# Altium schematic sheet Sheet1.SchDoc(Sheet1)
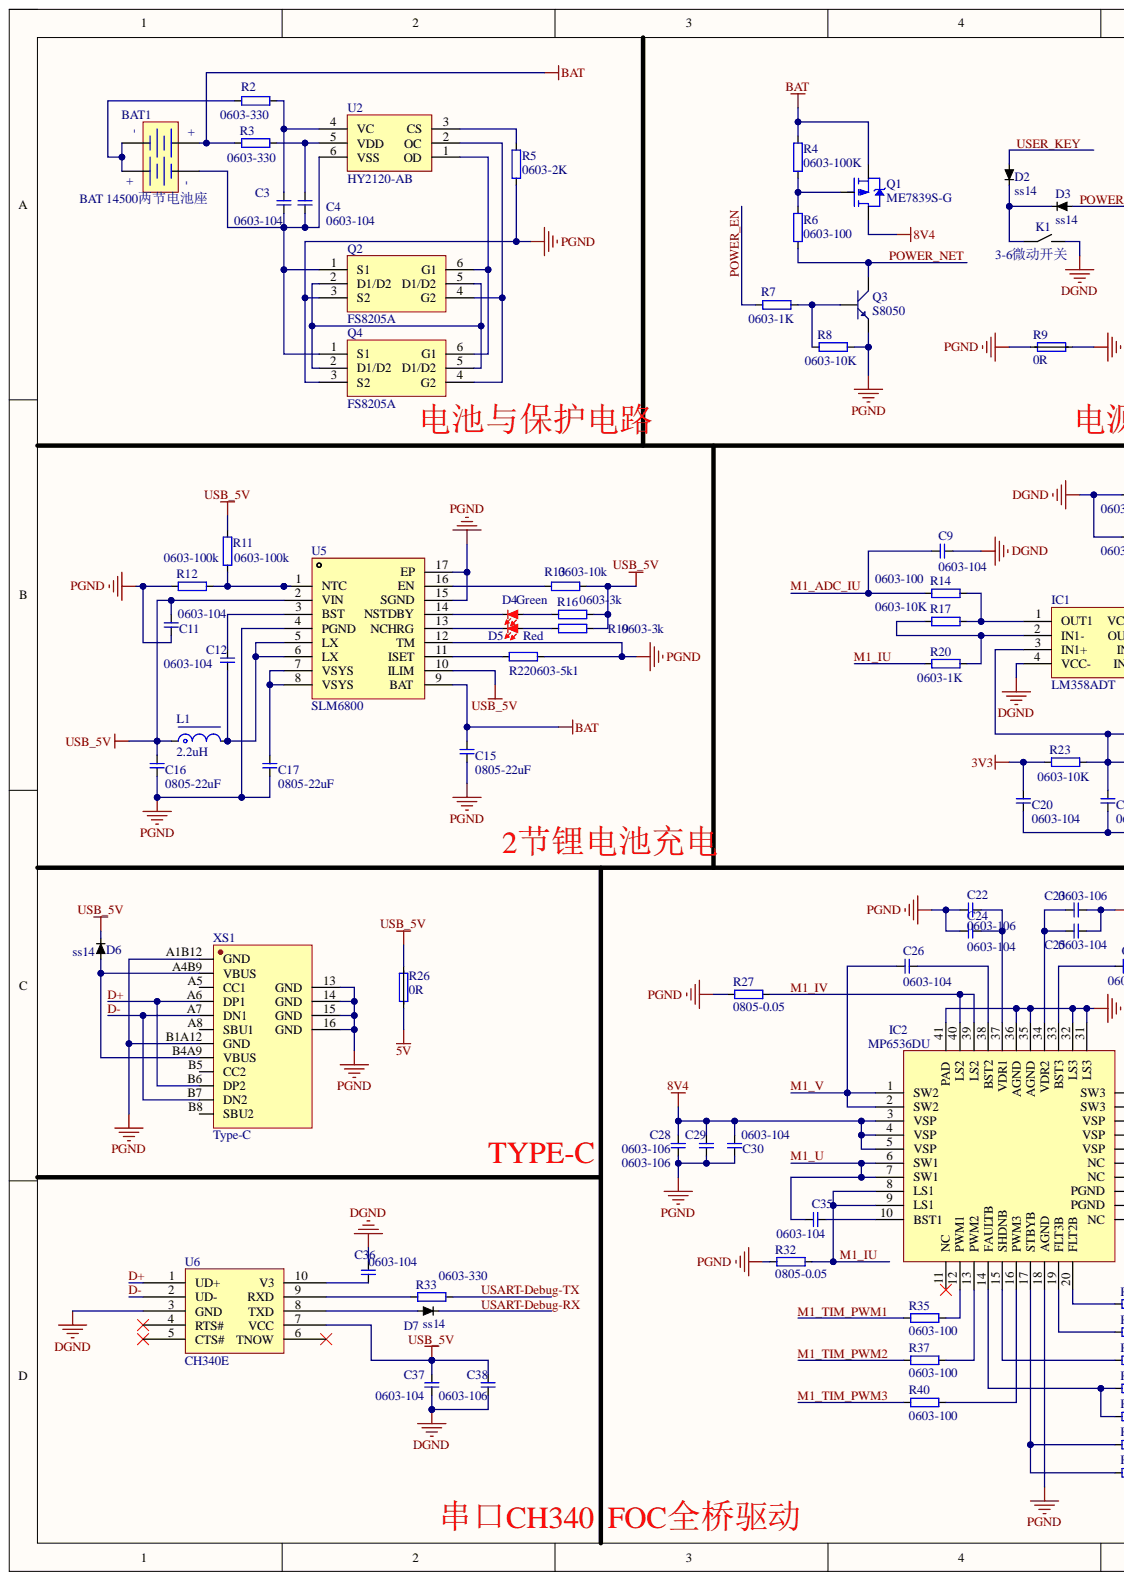
[diagram p0: Sheet1.SchDoc, left]
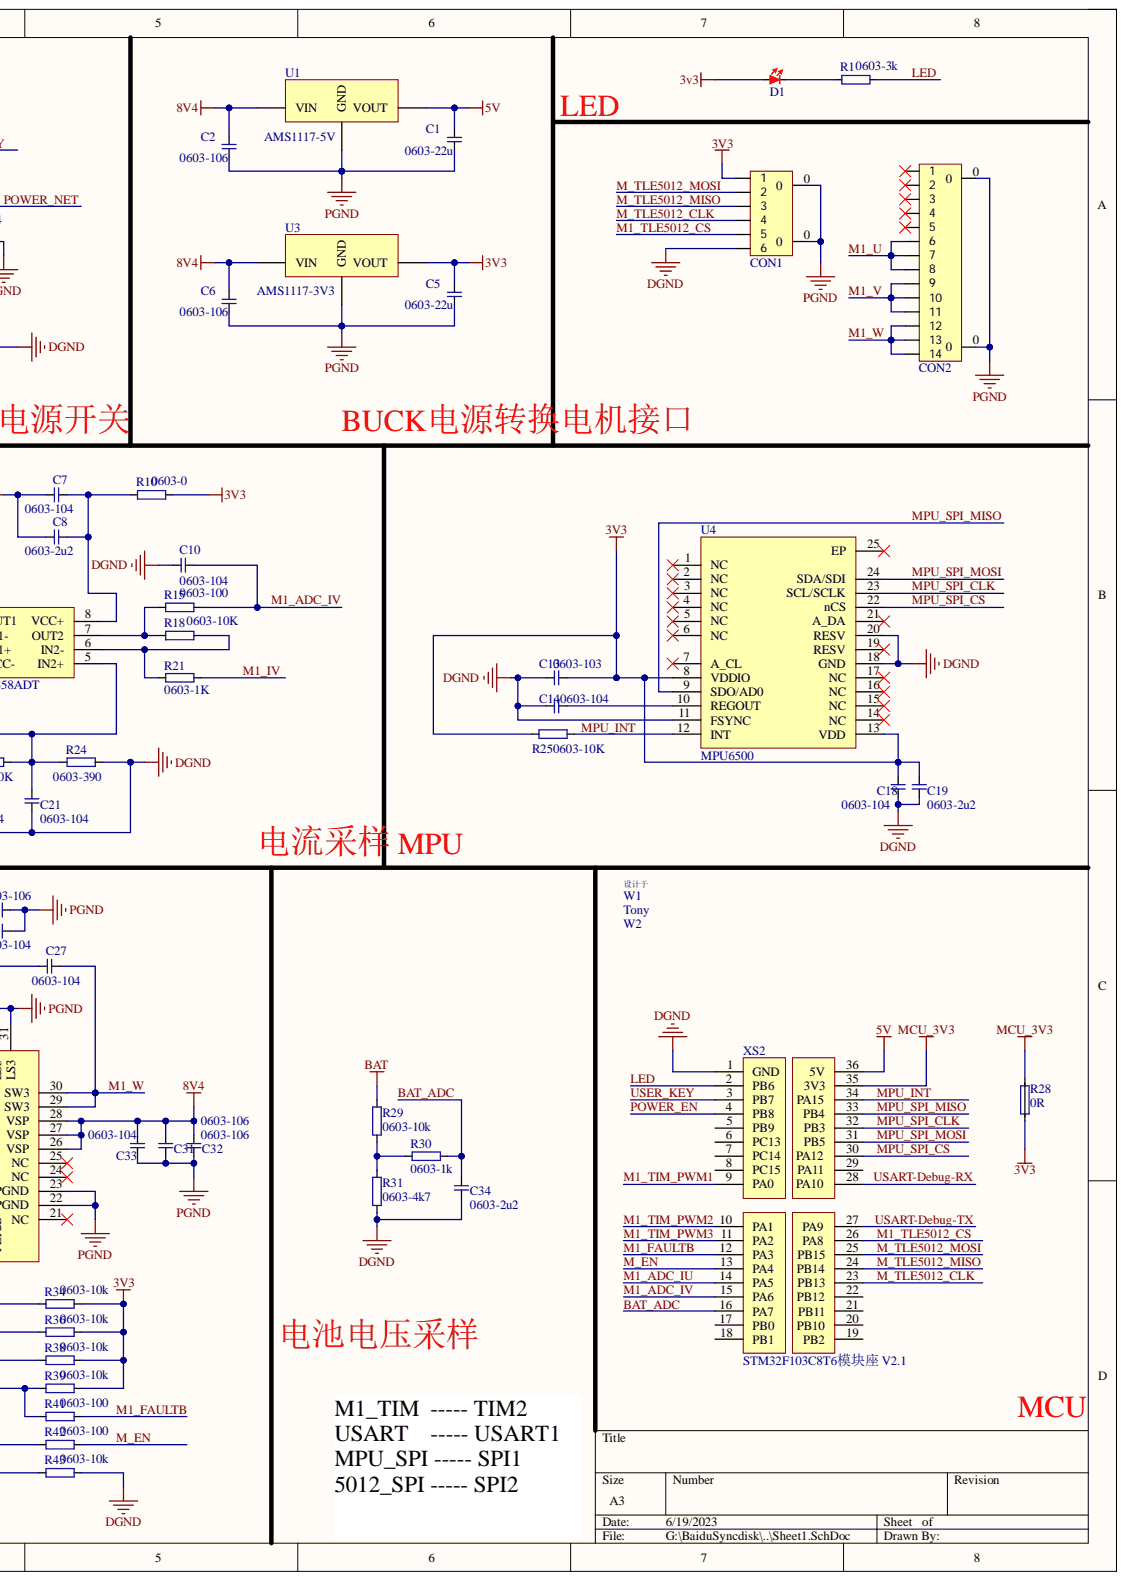
[diagram p1: Sheet1.SchDoc, right]

## Parts
BAT1  BAT 14500两节电池座  [BAT5#x2 电池座]
C1  0603-22u  [CAP_0603]
C2  0603-106  [CAP_0603]
C3  0603-104  [CAP_0603]
C4  0603-104  [CAP_0603]
C5  0603-22u  [CAP_0603]
C6  0603-106  [CAP_0603]
C7  0603-104  [CAP_0603]
C8  0603-2u2  [CAP_0603]
C9  0603-104  [CAP_0603]
C10  0603-104  [CAP_0603]
C11  0603-104  [CAP_0603]
C12  0603-104  [CAP_0603]
C13  0603-103  [CAP_0603]
C14  0603-104  [CAP_0603]
C15  0805-22uF  [CAP_0805]
C16  0805-22uF  [CAP_0805]
C17  0805-22uF  [CAP_0805]
C18  0603-104  [CAP_0603]
C19  0603-2u2  [CAP_0603]
C20  0603-104  [CAP_0603]
C21  0603-104  [CAP_0603]
C22  0603-106  [CAP_0603]
C23  0603-106  [CAP_0603]
C24  0603-104  [CAP_0603]
C25  0603-104  [CAP_0603]
C26  0603-104  [CAP_0603]
C27  0603-104  [CAP_0603]
C28  0603-106  [CAP_0603]
C29  0603-106  [CAP_0603]
C30  0603-104  [CAP_0603]
C31  0603-106  [CAP_0603]
C32  0603-106  [CAP_0603]
C33  0603-104  [CAP_0603]
C34  0603-2u2  [CAP_0603]
C35  0603-104  [CAP_0603]
C36  0603-104  [CAP_0603]
C37  0603-104  [CAP_0603]
C38  0603-106  [CAP_0603]
CON1  FFC_0.6  [FFC_0.5_6]  (value not drawn)
CON2  FFC_0.5_14  [FFC_0.5_14]  (value not drawn)
D1  led  [led0603]  (value not drawn)
D2  ss14  [SOD-323]
D3  ss14  [SOD-323]
D4  Green  [led0603]
D5  Red  [led0603]
D6  ss14  [SOD-323]
D7  ss14  [SOD-323]
IC1  LM358ADT  [SOP8_N]
IC2  MP6536DU  [QFN-40_5X5]
K1  3-6微动开关  [3-6微动开关]
L1  2.2uH  [NR4012]
Q1  ME7839S-G  [SOT23]
Q2  FS8205A  [SOT23-6]
Q3  S8050  [SOT23]
Q4  FS8205A  [SOT23-6]
R1  0603-3k  [RES_0603]
R2  0603-330  [RES_0603]
R3  0603-330  [RES_0603]
R4  0603-100K  [RES_0603]
R5  0603-2K  [RES_0603]
R6  0603-100  [RES_0603]
R7  0603-1K  [RES_0603]
R8  0603-10K  [RES_0603]
R9  0R  [RES_0805-SHORT]
R10  0603-0  [RES_0603]
R11  0603-100k  [RES_0603]
R12  0603-100k  [RES_0603]
R13  0603-10k  [RES_0603]
R14  0603-100  [RES_0603]
R15  0603-100  [RES_0603]
R16  0603-3k  [RES_0603]
R17  0603-10K  [RES_0603]
R18  0603-10K  [RES_0603]
R19  0603-3k  [RES_0603]
R20  0603-1K  [RES_0603]
R21  0603-1K  [RES_0603]
R22  0603-5k1  [RES_0603]
R23  0603-10K  [RES_0603]
R24  0603-390  [RES_0603]
R25  0603-10K  [RES_0603]
R26  0R  [RES_0805-SHORT]
R27  0805-0.05  [RES_0805]
R28  0R  [RES_0805-SHORT]
R29  0603-10k  [RES_0603]
R30  0603-1k  [RES_0603]
R31  0603-4k7  [RES_0603]
R32  0805-0.05  [RES_0805]
R33  0603-330  [RES_0603]
R34  0603-10k  [RES_0603]
R35  0603-100  [RES_0603]
R36  0603-10k  [RES_0603]
R37  0603-100  [RES_0603]
R38  0603-10k  [RES_0603]
R39  0603-10k  [RES_0603]
R40  0603-100  [RES_0603]
R41  0603-100  [RES_0603]
R42  0603-100  [RES_0603]
R43  0603-10k  [RES_0603]
U1  AMS1117-5V  [SOT223]
U2  HY2120-AB  [SOT23-6]
U3  AMS1117-3V3  [SOT223]
U4  MPU6500  [QFN-24_3X3]
U5  SLM6800  [QFN-16_4x4]
U6  CH340E  [MSOP10]
W1  设计于  [designed by（430-70）]
W2  Tony  [Tony_2(200-70)]
XS1  Type-C  [TypeC-304-16BCP]
XS2  STM32F103C8T6模块座 V2.1  [STM32F103C8T6 V2_1座子]

## Nets
3v3: C5.2 C13.2 C18.2 C19.2 C20.1 CON1.1 D1.1 R10.2 R23.2 R25.1 R28.1 R34.2 R36.2 R38.2 R39.2 U3.2 U4.8(VDDIO) U4.13(VDD)
5V: C1.2 R26.1 U1.2 XS2.36(5V)
8V4: C2.2 C6.2 C28.2 C29.2 C30.2 C31.2 C32.2 C33.2 IC2.3(VSP) IC2.4(VSP) IC2.5(VSP) IC2.26(VSP) IC2.27(VSP) IC2.28(VSP) Q1.3 U1.3 U3.3
BAT: BAT1.3 C15.2 Q1.2 R3.1 R4.2 R29.2 U5.9(BAT)
DGND: C7.1 C8.1 C9.1 C10.1 C13.1 C14.1 C18.1 C19.1 C20.2 C21.2 C34.1 C36.2 C37.1 C38.1 CON1.6 IC1.4(VCC-) K1.2 R9.1 R24.1 R31.1 R43.2 U4.11(FSYNC) U4.18(GND) U4.20(RESV) U6.3(GND) XS2.1(GND)
MCU_3V3: R28.2 XS2.35(3V3)
PGND: C1.1 C2.1 C5.1 C6.1 C11.2 C15.1 C16.1 C17.1 C22.1 C23.1 C24.1 C25.1 C28.1 C29.1 C30.1 C31.1 C32.1 C33.1 CON1.0 CON2.0 IC2.18(AGND) IC2.22(PGND) IC2.23(PGND) IC2.31(LS3) IC2.32(LS3) IC2.35(AGND) IC2.36(AGND) IC2.41(PAD) Q2.3(S2) Q3.2 Q4.3(S2) R5.1 R8.1 R9.2 R12.2 R22.1 R27.1 R32.1 U1.1 U3.1 U5.4(PGND) U5.12(TM) U5.15(SGND) U5.17(EP) XS1.13(GND) XS1.14(GND) XS1.15(GND) XS1.16(GND) XS1.A1B12(GND) XS1.B1A12(GND)
USB_5V: C11.1 C16.2 C37.2 C38.2 D6.2 L1.1 R11.2 R13.1 R16.1 R19.1 R26.2 U5.2(VIN) U5.10(ILIM) U6.7(VCC)
BAT_ADC: C34.2 R30.1 XS2.16(PA7)
D+: U6.1(UD+) XS1.A6(DP1) XS1.B6(DP2)
D-: U6.2(UD-) XS1.A7(DN1) XS1.B7(DN2)
LED: R1.2 XS2.2(PB6)
M1_ADC_IU: C9.2 R14.2 XS2.14(PA5)
M1_ADC_IV: C10.2 R15.2 XS2.15(PA6)
M1_FAULTB: R41.2 XS2.12(PA3)
M1_IU: IC2.8(LS1) IC2.9(LS1) R20.2 R32.2
M1_IV: IC2.39(LS2) IC2.40(LS2) R21.2 R27.2
M1_TIM_PWM1: R35.1 XS2.9(PA0)
M1_TIM_PWM2: R37.1 XS2.10(PA1)
M1_TIM_PWM3: R40.1 XS2.11(PA2)
M1_TLE5012_CS: CON1.5 XS2.26(PA8)
M1_U: C35.2 CON2.6 CON2.7 CON2.8 IC2.6(SW1) IC2.7(SW1)
M1_V: C26.1 CON2.9 CON2.10 CON2.11 IC2.1(SW2) IC2.2(SW2)
M1_W: C27.1 CON2.12 CON2.13 CON2.14 IC2.29(SW3) IC2.30(SW3)
M_EN: R42.2 XS2.13(PA4)
M_TLE5012_CLK: CON1.4 XS2.23(PB13)
M_TLE5012_MISO: CON1.3 XS2.24(PB14)
M_TLE5012_MOSI: CON1.2 XS2.25(PB15)
MPU_INT: R25.2 U4.12(INT) XS2.34(PA15)
MPU_SPI_CLK: U4.23(SCL/SCLK) XS2.32(PB3)
MPU_SPI_CS: U4.22(nCS) XS2.30(PA12)
MPU_SPI_MISO: U4.9(SDO/AD0) XS2.33(PB4)
MPU_SPI_MOSI: U4.24(SDA/SDI) XS2.31(PB5)
POWER_EN: R7.2 XS2.4(PB8)
POWER_NET: D3.1 Q3.3 R6.1
USART-Debug-RX: D7.1 XS2.28(PA10)
USART-Debug-TX: R33.2 XS2.27(PA9)
USER_KEY: D2.1 XS2.3(PB7)
NetBAT1_1: BAT1.1 BAT1.4 R2.1
NetBAT1_2: BAT1.2 C3.1 C4.1 Q2.1(S1) Q4.1(S1) U2.6(VSS)
NetC3_2: C3.2 R2.2 U2.4(VC)
NetC4_2: C4.2 R3.2 U2.5(VDD)
NetC7_2: C7.2 C8.2 IC1.8(VCC+) R10.1
NetC12_1: C12.1 L1.2 U5.5(LX) U5.6(LX)
NetC12_2: C12.2 U5.3(BST)
NetC14_2: C14.2 U4.10(REGOUT)
NetC17_2: C17.2 U5.7(VSYS) U5.8(VSYS)
NetC21_1: C21.1 IC1.3(IN1+) IC1.5(IN2+) R23.1 R24.2
NetC22_2: C22.2 C24.2 IC2.37(VDR1)
NetC23_2: C23.2 C25.2 IC2.34(VDR2)
NetC26_2: C26.2 IC2.38(BST2)
NetC27_2: C27.2 IC2.33(BST3)
NetC35_1: C35.1 IC2.10(BST1)
NetC36_1: C36.1 U6.10(V3)
NetD1_2: D1.2 R1.1
NetD2_2: D2.2 D3.2 K1.1
NetD4_1: D4.1 R16.2
NetD4_2: D4.2 U5.14(NSTDBY)
NetD5_1: D5.1 R19.2
NetD5_2: D5.2 U5.13(NCHRG)
NetD6_1: D6.1 XS1.A4B9(VBUS) XS1.B4A9(VBUS)
NetD7_2: D7.2 U6.8(TXD)
NetIC1_1: IC1.1(OUT1) R14.1 R17.1
NetIC1_2: IC1.2(IN1-) R17.2 R20.1
NetIC1_6: IC1.6(IN2-) R18.2 R21.1
NetIC1_7: IC1.7(OUT2) R15.1 R18.1
NetIC2_12: IC2.12(PWM1) R35.2
NetIC2_13: IC2.13(PWM2) R37.2
NetIC2_14: IC2.14(FAULTB) R39.1 R41.1
NetIC2_15: IC2.15(SHDNB) R38.1
NetIC2_16: IC2.16(PWM3) R40.2
NetIC2_17: IC2.17(STBYB) R42.1 R43.1
NetIC2_19: IC2.19(FLT3B) R36.1
NetIC2_20: IC2.20(FLT2B) R34.1
NetQ1_1: Q1.1 R4.1 R6.2
NetQ2_2: Q2.2(D1/D2) Q2.5(D1/D2) Q4.2(D1/D2) Q4.5(D1/D2)
NetQ2_4: Q2.4(G2) Q4.4(G2) U2.2(OC)
NetQ2_6: Q2.6(G1) Q4.6(G1) U2.1(OD)
NetQ3_1: Q3.1 R7.1 R8.2
NetR5_2: R5.2 U2.3(CS)
NetR11_1: R11.1 R12.1 U5.1(NTC)
NetR13_2: R13.2 U5.16(EN)
NetR22_2: R22.2 U5.11(ISET)
NetR29_1: R29.1 R30.2 R31.2
NetR33_1: R33.1 U6.9(RXD)

Not connected: CON2 1 2 3 4 5; IC2 11(NC) 21(NC) 24(NC) 25(NC); U4 1(NC) 2(NC) 3(NC) 4(NC) 5(NC) 6(NC) 7(A_CL) 14(NC) 15(NC) 16(NC) 17(NC) 19(RESV) 21(A_DA) 25(EP); U6 4(RTS#) 5(CTS#) 6(TNOW); XS1 A5(CC1) A8(SBU1) B5(CC2) B8(SBU2); XS2 5(PB9) 6(PC13) 7(PC14) 8(PC15) 17(PB0) 18(PB1) 19(PB2) 20(PB10) 21(PB11) 22(PB12) 29(PA11)

## Library data of the parts
BAT1 = BAT5#x2电池座: Component Kind: Standard; Library Name: SOURCE.IntLib
C1-C38 = cap: Component Kind: Standard; Library Name: 基本元件库.IntLib
CON1 = FFC_0.5_6: FFC; System: Connector; Component Kind: Standard; Library Name: 接插件库.IntLib
CON2 = FFC_0.5_14: FFC; System: Connector; Component Kind: Standard; Library Name: 接插件库.IntLib
D2 D3 D6 D7 = Diode: Component Kind: Standard; Library Name: 基本元件库.IntLib
D4 D5 = led: Component Kind: Standard; Library Name: 基本元件库.IntLib
IC1 = LM358ADT: 双通道运放-电流采样芯片; BOM名称: 双通道运放-电流采样芯片; Component Kind: Standard; Library Name: IC.IntLib
IC2 = MP6536DU: 三通道板桥驱动芯片; Component Kind: Standard; Library Name: IC.IntLib
K1 = 3-6微动开关: 3*6mm; Component Kind: Standard; Library Name: 基本元件库.IntLib
L1 = INDUCTOR: Component Kind: Standard; Library Name: 基本元件库.IntLib
Q1 = SI2333DS: P沟道MOSFIT; Component Kind: Standard; Library Name: 基本元件库.IntLib
Q2 Q4 = FS8205A: 2个N沟道 6A功率mos; Component Kind: Standard; Library Name: SOURCE.IntLib
Q3 = NPN: Component Kind: Standard; Library Name: 基本元件库.IntLib
R1-R8 R10-R25 R27 R29-R43 = Res: Component Kind: Standard; Library Name: 基本元件库.IntLib
R9 R26 R28 = Res-SHORT: Component Kind: Standard; Library Name: 基本元件库.IntLib
U1 U3 = AMS1117: Component Kind: Standard; Library Name: SOURCE.IntLib
U2 = HY2120: Component Kind: Standard; Library Name: SOURCE.IntLib
U4 = MPU6500: 六轴传感器; Component Kind: Standard; Library Name: IC.IntLib
U5 = SLM6800: 双锂电池充电芯片; Component Kind: Standard; Library Name: SOURCE.IntLib
U6 = CH340E: USB转串口 无外置晶振; Component Kind: Standard; Library Name: IC.IntLib
XS1 = Type-C母口: Component Kind: Standard; Library Name: 接插件库.IntLib
D1 = led: Component Kind: Standard; Library Name: 基本元件库.IntLib
W1 = 设计于: Component Kind: Standard; Library Name: 丝印库.IntLib
W2 = Tony: Component Kind: Standard; Library Name: 丝印库.IntLib
XS2 = STM32F103C8T6模块座 V2.1: Component Kind: Standard; Library Name: 第一次绘制库.IntLib
